# Altium schematic sheet UniController.SchDoc
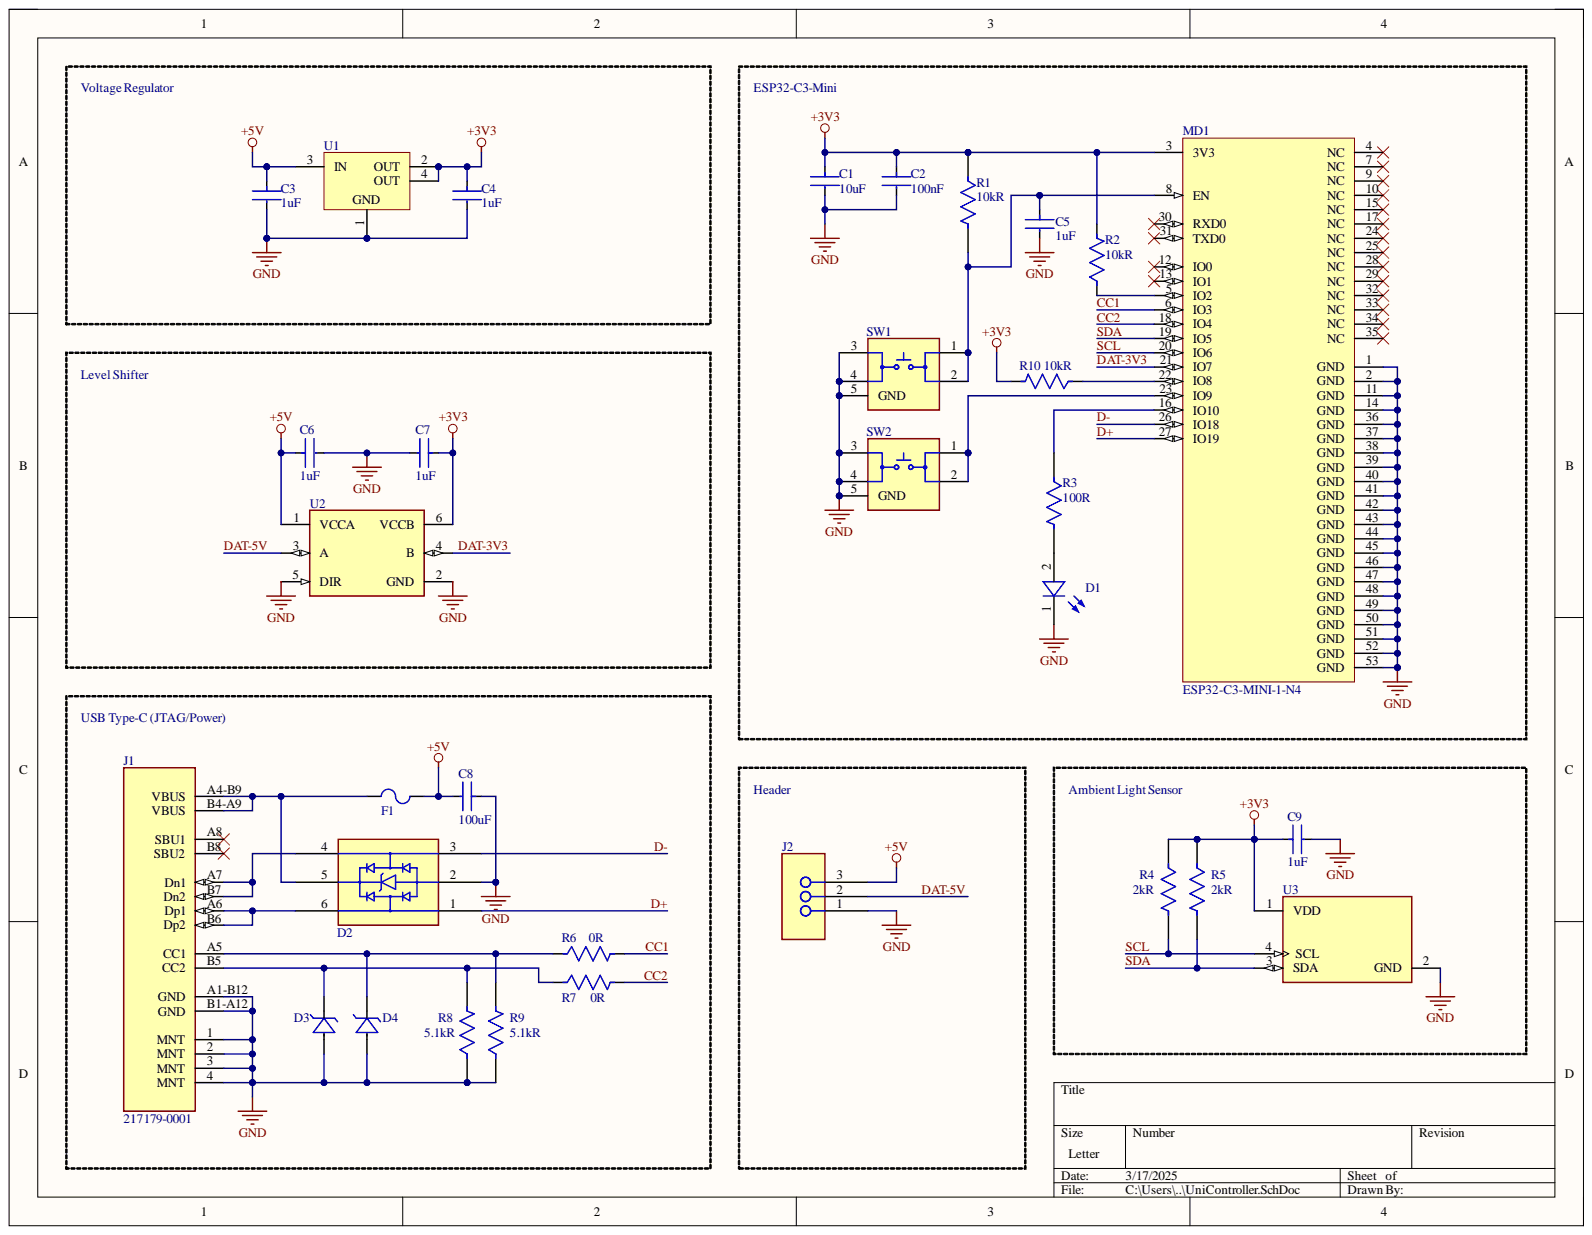
[diagram p0: UniController.SchDoc]

## Parts
C1  Capacitance: 10uF  [FP-CL21-IPC_B]  (hidden comment: CL21A106KOQNNNE)
C2  Capacitance: 100nF  [FP-CL830-IPC_C]  (hidden comment: CL05B104KA5NNNC)
C3  value 1uF  Capacitance: 1uF  [FP-CL10B105KO8NNNC-MFG]  (hidden comment: CL10B105KO8NNNC)
C4  value 1uF  Capacitance: 1uF  [FP-CL10B105KO8NNNC-MFG]  (hidden comment: CL10B105KO8NNNC)
C5  value 1uF  Capacitance: 1uF  [FP-CL10B105KO8NNNC-MFG]  (hidden comment: CL10B105KO8NNNC)
C6  value 1uF  Capacitance: 1uF  [FP-CL10B105KO8NNNC-MFG]  (hidden comment: CL10B105KO8NNNC)
C7  value 1uF  Capacitance: 1uF  [FP-CL10B105KO8NNNC-MFG]  (hidden comment: CL10B105KO8NNNC)
C8  Capacitance: 100uF  [FP-GRM31C-0_3-e0_3_0_8-MFG]  (hidden comment: GRM31CR60J107MEA8K)
C9  value 1uF  Capacitance: 1uF  [FP-CL10B105KO8NNNC-MFG]  (hidden comment: CL10B105KO8NNNC)
D1  150060BS55040  [WL-SMCD_0603]  (value not drawn)
D2  USBLC6-2SC6  [SOT23-6L_STM]  (value not drawn)
D3  ESD321DYAR  [SOT5x3_DYA_TEX]  (value not drawn)
D4  ESD321DYAR  [SOT5x3_DYA_TEX]  (value not drawn)
F1  0ZCG0300FF2B  [0ZCG_BEL]  (value not drawn)
J1  217179-0001  [FP-2171790001-MFG]
J2  Header 3 pin  [Header 3 pin-Footprint-1]  (value not drawn)  (no BOM)
MD1  ESP32-C3-MINI-1-N4  [FP-ESP32-C3-MINI-1-N4-MFG]
R1  value 10kR  [RESC1005X40X25LL05T05]  (hidden comment: ERJ-2RKF1002X)
R2  value 10kR  [RESC1005X40X25LL05T05]  (hidden comment: ERJ-2RKF1002X)
R3  value 100R  [RESC1005X40X25NL05T10]  (hidden comment: RC0402JR-07100RL)
R4  value 2kR  [FP-ERJ2RK-MFG]  (hidden comment: ERJ-2RKF2001X)
R5  value 2kR  [FP-ERJ2RK-MFG]  (hidden comment: ERJ-2RKF2001X)
R6  value 0R  [RESC1005X40X25LL05T05]  (hidden comment: ERJ-2GE0R00X)
R7  value 0R  [RESC1005X40X25LL05T05]  (hidden comment: ERJ-2GE0R00X)
R8  Resistance: 5.1kR  [FP-ERJ3EK-MFG]  (hidden comment: ERJ-3EKF5101V)
R9  Resistance: 5.1kR  [FP-ERJ3EK-MFG]  (hidden comment: ERJ-3EKF5101V)
R10  value 10kR  [RESC1005X40X25LL05T05]  (hidden comment: ERJ-2RKF1002X)
SW1  KMR211GLFS  [KMR2xxG_CNK]  (value not drawn)
SW2  KMR211GLFS  [KMR2xxG_CNK]  (value not drawn)
U1  TLV1117LV33DCYR  [DCY0004A_N]  (value not drawn)
U2  SN74LVC1T45DBVR  [FP-DBV0006A-IPC_B]  (value not drawn)
U3  LTR-329ALS-01  [FP-LTR-329ALS-01-MFG]  (value not drawn)

## Nets
+3V3: C1.1 C2.1 C4.1 C7.1 C9.1 MD1.3(3V3) R1.1 R2.2 R4.1 R5.1 R10.2 U1.2(OUT) U1.4(OUT) U2.6(VCCB) U3.1(VDD)
+5V: C3.1 C6.1 C8.1 F1.1 J2.3 U1.3(IN) U2.1(VCCA)
GND: C1.2 C2.2 C3.2 C4.2 C5.2 C6.2 C7.2 C8.2 C9.2 D1.1 D2.2 D3.1 D4.1 J1.1(MNT) J1.2(MNT) J1.3(MNT) J1.4(MNT) J1.A1-B12(GND) J1.B1-A12(GND) J2.1 MD1.1(GND) MD1.2(GND) MD1.11(GND) MD1.14(GND) MD1.36(GND) MD1.37(GND) MD1.38(GND) MD1.39(GND) MD1.40(GND) MD1.41(GND) MD1.42(GND) MD1.43(GND) MD1.44(GND) MD1.45(GND) MD1.46(GND) MD1.47(GND) MD1.48(GND) MD1.49(GND) MD1.50(GND) MD1.51(GND) MD1.52(GND) MD1.53(GND) R8.1 R9.1 SW1.3 SW1.4 SW1.5(GND) SW2.3 SW2.4 SW2.5(GND) U1.1 U2.2(GND) U2.5(DIR) U3.2(GND)
CC1: MD1.6(IO3) R6.2
CC2: MD1.18(IO4) R7.2
D+: D2.1 MD1.27(IO19)
D-: D2.3 MD1.26(IO18)
DAT-3V3: MD1.21(IO7) U2.4(B)
DAT-5V: J2.2 U2.3(A)
SCL: MD1.20(IO6) R4.2 U3.4(SCL)
SDA: MD1.19(IO5) R5.2 U3.3(SDA)
NetC5_1: C5.1 MD1.8(EN) R1.2 SW1.1 SW1.2
NetD1_2: D1.2 R3.2
NetD2_4: D2.4 J1.A7(Dn1) J1.B7(Dn2)
NetD2_5: D2.5 F1.2 J1.A4-B9(VBUS) J1.B4-A9(VBUS)
NetD2_6: D2.6 J1.A6(Dp1) J1.B6(Dp2)
NetD3_2: D3.2 J1.B5(CC2) R7.1 R8.2
NetD4_2: D4.2 J1.A5(CC1) R6.1 R9.2
NetMD1_5: MD1.5(IO2) R2.1
NetMD1_16: MD1.16(IO10) R3.1
NetMD1_22: MD1.22(IO8) R10.1
NetMD1_23: MD1.23(IO9) SW2.1 SW2.2

Not connected: J1 A8(SBU1) B8(SBU2); MD1 4(NC) 7(NC) 9(NC) 10(NC) 12(IO0) 13(IO1) 15(NC) 17(NC) 24(NC) 25(NC) 28(NC) 29(NC) 30(RXD0) 31(TXD0) 32(NC) 33(NC) 34(NC) 35(NC)

## Library data of the parts
C1 = CMP-2000-06102-2: CL21 Series 0805 10uF 16V ±10% Tolerance X5R Multilayer Ceramic Chip Capacitor; Dielectric: X7R; Case Code (Imperial): 0805; Case Code (Metric): 2012; Case/Package: 0805; Component Kind: Standard; Depth: 1.25mm; Height: 1.25mm; Lead Free: Lead Free; Length: 2mm; Library Name: Altium Content Vault; Max Operating Temperature: 85°C; Min Operating Temperature: -55°C; Mount: Surface Mount; Package Quantity: 1; Packaging: Tape and Reel; Radiation Hardening: No; Thickness: 0.055inch; Tolerance: 10%; Voltage: 16V; Voltage Rating: 16V; Voltage Rating (DC): 16V; Width: 0.049inch
C2 = CMP-13271-001643-1: Cap Ceramic 100nF 25V X7R ±10% SMD 0402 +125°C Paper T/R; Case Code (Imperial): 0402; Case Code (Metric): 1005; Case/Package: 0402; Component Kind: Standard; Length: 0.039inch; Library Name: Altium Content Vault; Max Operating Temperature: 125°C; Min Operating Temperature: -55°C; Mount: Surface Mount; Packaging: Tape and Reel; Thickness: 0.022inch; Tolerance: 10%; Voltage Rating: 25V; Voltage Rating (DC): 25V; Width: 0.02inch
C3-C7 C9 = CMP-2000-06093-2: CAP CER 1UF 16V X7R 0603; Manufacturer: Samsung Electro-Mechanics America, Inc; Material: Ceramic; Temperature Coefficient: 15%; Tolerance: 10%; Automotive: No; Category: Cap; Component Kind: Standard; ComponentLink2Description: Datasheet; ComponentLink2URL: http://www.samsungsem.com/global/front/downloadcms.do?path=%2F%2Fglobal%2F%2Fsupport%2F%2Fproduct-search%2F%2Fmlcc%2F%2F__icsFiles%2F%2Fafieldfile%2F%2F2019%2F%2F06%2F%2F18&fileName=CL10B105KO8NNNC-19.pdf; Device Class L1: Passive Components; Device Class L2: Capacitors; Device Class L3: Ceramic Capacitors; Digikey Description: CAP CER 1UF 16V X7R 0603; Height: 0.9mm; Ipc Land Pattern Name: CAPC16080X80; Lead Free: Yes; Library Name: Altium Content Vault; Manufacturer Part Number: CL10B105KO8NNNC; Octopart: https://octopart.com/cl10b105ko8nnnc-samsung-19018973; Package: CL10B105KO8NNNC; RoHS: TRUE; Temperature: -55°C to +125°C; Temperature Characteristic: X7R; Temperature Range High: +125°C; Temperature Range Low: -55°C; Voltage Rating: 16V
C8 = CMP-06035-034850-1: Chip Multilayer Ceramic Capacitors for General Purpose, 1206, 100uF, X5R, 15%, 20%, 6.3V; Component Kind: Standard; Library Name: Altium Content Vault
D1 = CMP-007-00036-6: Single Color LED; Component Kind: Standard; RoHS Compliant: Yes; Library Name: Graham Shupe
D2 = CMP-011-000000-3: No Description Available; Mfr_Name: STMicroelectronics; Component Kind: Standard; Component Type: Standard; Design Item ID: USBLC6-2SC6; Pin Count: 6; RefDes: RefDes; Source: USBLC6-2SC6.SchLib; Type: Type; Library Name: Graham Shupe
D3 D4 = CMP-006-000000-6: USB 2.0 TVS diode, 0.9 pF, 30kV air/contact; Mfr_Name: Texas Instruments; Component Kind: Standard; Component Type: Standard; Design Item ID: ESD321DYAR; Pin Count: 2; Source: ESD321DYAR.SchLib; Library Name: Graham Shupe
F1 = CMP-005-00013-3: PTC Fuse 6V 3A hold 5A trip; Mfr_Name: Belfuse; Component Kind: Standard; Component Type: Standard; Design Item ID: 3A Polyfuse; Pins: 2; Source: 0ZCG0300FF2B.SchLib; Library Name: Graham Shupe
J1 = CMP-002-00072-4: USB Connector, 16 Contact(s), Female, Right Angle, Surface Mount Terminal, Locking, Receptacle; Component Kind: Standard; Library Name: Graham Shupe
J2 = CMP-002-00071-4: 3x1 pins; Pins: 3; Library Name: Graham Shupe
MD1 = CMP-020-00023-3: Multiprotocol Modules SMD module, ESP32-C3FN4, PCB antenna, -40 C +85 C; Component Kind: Standard; Library Name: Graham Shupe
R1 = CMP-009-00177-1: Fixed Resistor, Metal Glaze/thick Film, 0.1W, 10000ohm, 50V, 1% +/-Tol, 100ppm/Cel, Surface Mount, 0402; Power: 100mW; Case/Package: 0402; Component Kind: Standard; Max Operating Temperature: 155°C; Min Operating Temperature: -55°C; Mounting Technology: Surface Mount; Pins: 2; RoHS Compliant: Yes; Tolerance: 1%; Library Name: Graham Shupe
R2 R10 = CMP-009-00177-2: Fixed Resistor, Metal Glaze/thick Film, 0.1W, 10000ohm, 50V, 1% +/-Tol, 100ppm/Cel, Surface Mount, 0402; Power: 100mW; Case/Package: 0402; Component Kind: Standard; Max Operating Temperature: 155°C; Min Operating Temperature: -55°C; Mounting Technology: Surface Mount; Pins: 2; RoHS Compliant: Yes; Tolerance: 1%; Library Name: Graham Shupe
R3 = CMP-009-00183-2: Fixed Resistor, Metal Glaze/thick Film, 0.0625W, 100ohm, 50V, 5% +/-Tol, 100ppm/Cel, Surface Mount, 0402; Power: 62.5mW; Case/Package: 0402; Component Kind: Standard; Max Operating Temperature: 155°C; Min Operating Temperature: -55°C; Mounting Technology: Surface Mount; Pins: 2; RoHS Compliant: Yes; Tolerance: 5%; Library Name: Graham Shupe
R4 R5 = CMP-009-00181-2: Fixed Resistor, Metal Glaze/thick Film, 0.1W, 2000ohm, 50V, 1% +/-Tol, 100ppm/Cel, Surface Mount, 0402; Power: 100mW; Case/Package: 0402; Component Kind: Standard; Max Operating Temperature: 155°C; Min Operating Temperature: -55°C; Mounting Technology: Surface Mount; Pins: 2; RoHS Compliant: Yes; Tolerance: 1%; Library Name: Graham Shupe
R6 R7 = CMP-009-00178-1: Fixed Resistor, Metal Glaze/thick Film, 0.1W, 0ohm, Surface Mount, 0402; Power: 100mW; Case/Package: 0402; Component Kind: Standard; Max Operating Temperature: 155°C; Min Operating Temperature: -55°C; Mounting Technology: Surface Mount; Pins: 2; RoHS Compliant: Yes; Library Name: Graham Shupe
R8 R9 = CMP-2000-00540-8: RES SMD 5.1K OHM 1% 1/10W 0603; Max Power Dissipation: 100mW; Temperature Coefficient: 100ppm/°C; Voltage Rating: 75V; Case Code (Imperial): 0603; Case Code (Metric): 1608; Case/Package: 0603; Component Kind: Standard; Construction: Rectangular; Contact Plating: Tin; Depth: 0.8mm; Features: Automotive AEC-Q200; Height: 0.022inch; Lead Free: Lead Free; Length: 1.6mm; Library Name: Altium Content Vault; Max Operating Temperature: 155°C; Military Standard: Not; Min Operating Temperature: -55°C; Mount: Surface Mount; Number of Terminations: 2; Package Quantity: 1; Packaging: Tape and Reel; Power Rating: 100mW; Radiation Hardening: No; Reach SVHC Compliant: Yes; Resistance Tolerance: 1%; Resistor Type: Thick Film; RoHS Compliant: Yes; Series: ERJ; Size Code: 0603; Termination: SMD/SMT; Tolerance: 1%; Voltage Rating (DC): 75V; Weight: 7.1E-05oz; Width: 0.8mm
SW1 SW2 = CMP-011-00013-2: Tactile Pushbutton Switch with Grounding Pad, 0.05A @ 32V, SPST-NO, Off-Mom; Mfr_Name: C&K Components; Component Kind: Standard; Component Type: Standard; Design Item ID: KMR211GLFS; Pins: 5; RefDes: RefDes; Source: KMR211GLFS.SchLib; Type: Type; Library Name: Graham Shupe
U1 = CMP-0395-00503-2: Single Output LDO, 1 A, Fixed 3.3 V Output, 2 to 5.5 V Input, 4-pin SOT-223 (DCY), -40 to 125 degC, Green (RoHS & no Sb/Br); Manufacturer: Texas Instruments; Accuracy(%): 2; Fixed Output Options(V): 3.3; Iout(Max)(A): 1; Iq(Typ)(mA): 0.05; SubFamily: Single Channel LDO; Vdo(Typ)(mV): 1200; Vin(Max)(V): 5.5; Code_JEDEC: TO-261; Component Kind: Standard; ComponentLink1Description: Manufacturer URL; ComponentLink1URL: http://www.ti.com/; ComponentLink2Description: Datasheet; ComponentLink2URL: http://www.ti.com/general/docs/lit/getliterature.tsp?genericPartNumber=TLV1117LV33&fileType=pdf; ComponentLink3Description: Package Specification; ComponentLink3URL: http://www.ti.com/litv/pdf/mpds094a; DatasheetVersion: SBVS160A; Eco-Plan: Green (RoHS & no Sb/Br); Library Name: Altium Content Vault; Mounting Technology: Surface Mount; PackageDescription: 4-Pin Plastic Small Outline, Body 3.5 x 6.5 mm, Pitch 2.3 mm; PackageReference: DCY0004A; PackageVersion: 4202506/B; PartNumber: TLV1117LV33DCYR; Vin(Min)(V): 2; Vout Adj(Max)(V): 3.3; Vout Adj(Min)(V): 3.3
U2 = CMP-0859-00206-4: IC TRNSLTR BIDIRECTIONAL SOT23-6; Data Rate: 420Mbps; High Level Output Current: -32mA; Logic Function: Translator; Low Level Output Current: 32mA; Max Supply Voltage: 5.5V; Min Supply Voltage: 1.65V; Number of Bits: 1; Polarity: Non-Inverting; Propagation Delay: 3.9ns; Quiescent Current: 3uA; Turn-On Delay Time: 36.2ns; Case/Package: SOT-23; Component Kind: Standard; Contact Plating: Gold; Direction: Bidirectional; Height: 1.75mm; Lead Free: Lead Free; Length: 3.05mm; Library Name: Altium Content Vault; Max Operating Temperature: 85°C; Min Operating Temperature: -40°C; Mount: Surface Mount; Number of Channels: 1; Number of Elements: 1; Number of Inputs: 1; Number of Pins: 6; Package Quantity: 3000; Packaging: Tape and Reel; Radiation Hardening: No; REACH SVHC: No SVHC; Weight: 0.000229oz; Width: 1.3mm
U3 = CMP-031-00006-2: Open-drain, CMOS, 4 Pin, Unspecified; Max Supply Voltage: 3.6V; Min Supply Voltage: 2.4V; Case/Package: BCC; Component Kind: Standard; Max Operating Temperature: 70°C; Min Operating Temperature: -30°C; Pins: 4; RoHS Compliant: Yes; Library Name: Graham Shupe
